# Altium schematic sheet schematic.SchDoc
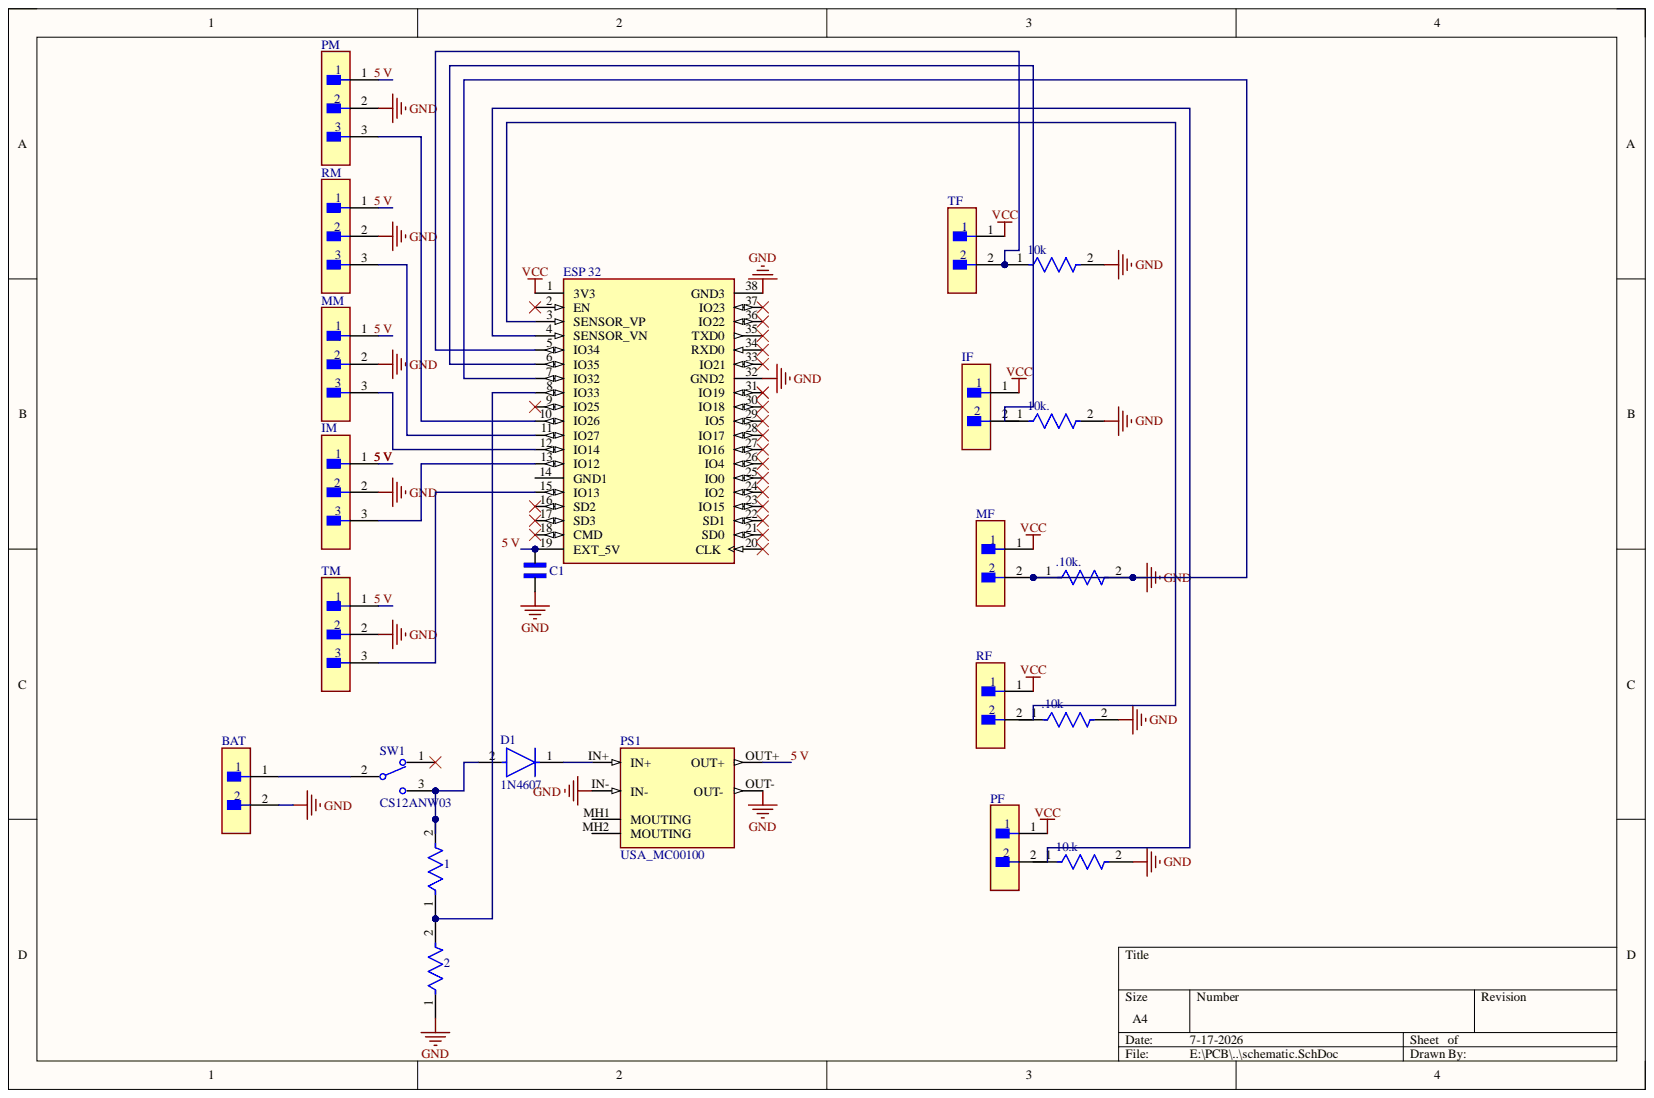
[diagram p0: schematic.SchDoc]

## Parts
1  VR37000001204JA100  [FP-VR37-MFG]  (value not drawn)
2  VR37000001204JA100  [FP-VR37-MFG]  (value not drawn)
10.k  VR37000001204JA100  [FP-VR37-MFG]  (value not drawn)
10k  VR37000001204JA100  [FP-VR37-MFG]  (value not drawn)
10k.  VR37000001204JA100  [FP-VR37-MFG]  (value not drawn)
.10k  VR37000001204JA100  [FP-VR37-MFG]  (value not drawn)
.10k.  VR37000001204JA100  [FP-VR37-MFG]  (value not drawn)
BAT  TSW-102-14-G-S  [FP-TSW-102-14-G-S-MFG]  (value not drawn)
C1  FA14X8R1H104KNU06  [CAPRB250W50L450T300H550]  (value not drawn)
D1  1N4607  [FP-DO-35-MFG]
ESP_32  ESP32-DEVKITC  [MODULE_ESP32-DEVKITC]  (value not drawn)
IF  TSW-102-14-G-S  [FP-TSW-102-14-G-S-MFG]  (value not drawn)
IM  NRPN031PAEN-RC  [FP-NRPN031PAEN-RC-MFG]  (value not drawn)
MF  TSW-102-14-G-S  [FP-TSW-102-14-G-S-MFG]  (value not drawn)
MM  NRPN031PAEN-RC  [FP-NRPN031PAEN-RC-MFG]  (value not drawn)
PF  TSW-102-14-G-S  [FP-TSW-102-14-G-S-MFG]  (value not drawn)
PM  NRPN031PAEN-RC  [FP-NRPN031PAEN-RC-MFG]  (value not drawn)
PS1  USA_MC00100  [CONV_USA_MC00100]
RF  TSW-102-14-G-S  [FP-TSW-102-14-G-S-MFG]  (value not drawn)
RM  NRPN031PAEN-RC  [FP-NRPN031PAEN-RC-MFG]  (value not drawn)
SW1  CS12ANW03  [FP-CS12ANW03-MFG]
TF  TSW-102-14-G-S  [FP-TSW-102-14-G-S-MFG]  (value not drawn)
TM  NRPN031PAEN-RC  [FP-NRPN031PAEN-RC-MFG]  (value not drawn)

## Nets
GND: 2.1 10.k.2 10k.2 10k..2 .10k.2 .10k..1 .10k..2 BAT.2 C1.2 ESP_32.7(IO32) ESP_32.32(GND2) ESP_32.38(GND3) IM.2 MF.2 MM.2 PM.2 PS1.IN- PS1.OUT- RM.2 TM.2
VCC: ESP_32.1(3V3) IF.1 MF.1 PF.1 RF.1 TF.1
5 V: C1.1 ESP_32.19(EXT_5V) IM.1 MM.1 PM.1 PS1.OUT+ RM.1 TM.1
Net1_1: 1.1 2.2 ESP_32.8(IO33)
Net1_2: 1.2 D1.2 SW1.3
Net10k._1: 10k..1 ESP_32.6(IO35)
Net10k_1: 10k.1 ESP_32.5(IO34) TF.2
NetBAT_1: BAT.1 SW1.2
NetD1_1: D1.1 PS1.IN+
NetESP 32_3: ESP_32.3(SENSOR_VP) RF.2
NetESP 32_4: ESP_32.4(SENSOR_VN) PF.2
NetESP 32_10: ESP_32.10(IO26) PM.3
NetESP 32_11: ESP_32.11(IO27) RM.3
NetESP 32_12: ESP_32.12(IO14) MM.3
NetESP 32_13: ESP_32.13(IO12) IM.3
NetESP 32_15: ESP_32.15(IO13) TM.3

Not connected: 10.k 1; .10k 1; ESP_32 2(EN) 9(IO25) 14(GND1) 16(SD2) 17(SD3) 18(CMD) 20(CLK) 21(SD0) 22(SD1) 23(IO15) 24(IO2) 25(IO0) 26(IO4) 27(IO16) 28(IO17) 29(IO5) 30(IO18) 31(IO19) 33(IO21) 34(RXD0) 35(TXD0) 36(IO22) 37(IO23); IF 2; PS1 MH1(MOUTING) MH2(MOUTING); SW1 1

## Library data of the parts
1 2 10.k 10k 10k. .10k .10k. = CMP-02408-000054-1: RES 1.2M OHM 5% 1/2W AXIAL; Power Rating: 500mW; Resistance: 1.2MR; Temperature Coefficient: 200ppm/°C; Voltage Rating: 3.5kV; Case/Package: Conformal; Component Kind: Standard; Construction: Cylindrical; Contact Plating: Tin; Diameter: 4mm; Length: 10mm; Library Name: Altium Content Vault; Max Operating Temperature: 155°C; Military Standard: Not; Min Operating Temperature: -55°C; Mount: Through Hole; Packaging: Ammo Pack; Radiation Hardening: No; Reach SVHC Compliant: Yes; Resistance Tolerance: 5%; Resistor Type: Metal Film; Termination: Axial; Tolerance: 5%; Voltage Rating (DC): 3.5kV
BAT IF MF PF RF TF = CMP-02766-001740-1: CONN HEADER VERT 2POS 2.54MM; Gender: Male; Max Current Rating: 7.6A; Max Voltage Rating (AC): 550V; Number of Contacts: 2; Color: Black; Component Kind: Standard; Connector Type: Header; Contact Finish - Mating: GOLD; Contact Material: Bronze; Contact Mating Length: 0.32inch; Contact Plating: Gold; Contact Shape: Square; Contact Type: Male Pin; Depth: 2.54mm; Fastening Type: Pull; Flammability Rating: UL94 V-0; Housing Color: Black; Housing Material: Polyester; Insulation Color: Black; Insulation Material: PBT; Lead Free: Lead Free; Length: 5.08mm; Library Name: Altium Content Vault; Max Operating Temperature: 125°C; Min Operating Temperature: -55°C; Mount: Through Hole; Number of Positions: 2; Number of Rows: 1; Orientation: Straight; Package Quantity: 1; Packaging: Bulk; Pitch: 2.54mm; Radiation Hardening: No; REACH SVHC: No SVHC; RoHS Compliant: Yes; Series: TSW; Termination: Solder; Width: 2.54mm
C1 = FA14X8R1H104KNU06: 0.1 ÂµF Â±10% 50V Ceramic Capacitor X8R Radial; MF: TDK; Check_prices: https://www.snapeda.com/parts/FA14X8R1H104KNU06/TDK/view-part/?ref=eda; Component Kind: Standard; Library Name: ceramic capacitor.IntLib; MP: FA14X8R1H104KNU06; Package: NON STANDARD-2 TDK; SnapEDA_Link: https://www.snapeda.com/parts/FA14X8R1H104KNU06/TDK/view-part/?ref=snap
D1 = CMP-00252-99173177-1: 1N4607 1N4607 Series 85 V 0.2 A Axial Silicon Switching Diode - DO-35; Average Rectified Current: 200mA; Element Configuration: Single; Forward Voltage: 1.1V; Max Forward Surge Current (Ifsm): 1A; Max Repetitive Reverse Voltage (Vrrm): 85V; Peak Reverse Current: 100µA; Reverse Recovery Time: 10ns; Component Kind: Standard; Contact Plating: Lead, Tin; Forward Current: 200mA; Height: 2.286mm; Library Name: Altium Content Vault; Max Operating Temperature: 200°C; Max Reverse Voltage (DC): 85V; Min Operating Temperature: -65°C; Mount: Through Hole; Number of Pins: 2; Packaging: Bulk; Peak Non-Repetitive Surge Current: 1A; Radiation Hardening: No; Reverse Voltage: 85V; RoHS: Compliant; Schedule B: 8541100070, 8541210080, 8541210080
ESP_32 = ESP32-DEVKITC: Transceiver; 802.11 b/g/n (Wi-Fi, WiFi, WLAN), BluetoothÂ® Smart Ready 4.x Dual Mode; MF: Espressif Systems; MP: ESP32-DEVKITC-32D-F; Check_prices: https://www.snapeda.com/parts/ESP32-DEVKITC-32D-F/Espressif+Systems/view-part/?ref=eda; Component Kind: Standard; Library Name: ESP32-DEVKITC.IntLib; Package: None; SnapEDA_Link: https://www.snapeda.com/parts/ESP32-DEVKITC-32D-F/Espressif+Systems/view-part/?ref=snap
IM MM PM RM TM = CMP-25680-000021-1: CONN HEADER VERT 3POS 2MM; Manufacturer: Sullins; Conn Gender: Male; Conn Orientation: Straight; Current Rating: 2A; Number Of Contacts: 3; Voltage Rating: 150V; Automotive: No; Category: Conn; Component Kind: Standard; ComponentLink2Description: Datasheet; ComponentLink2URL: https://drawings-pdf.s3.amazonaws.com/10531.pdf; Contact Material: Gold,Nickel; Device Class L1: Connectors; Device Class L2: Headers and Wire Housings; Device Class L3: unset; Digikey Description: CONN HEADER VERT 3POS 2MM; Height: 6mm; Lead Free: Yes; Library Name: Altium Content Vault; Manufacturer Part Number: NRPN031PAEN-RC; Number Of Rows: 1; Octopart: https://octopart.com/nrpn031paen-rc-sullins-12817732; Package: 0; Pitch: 2mm; RoHS: TRUE; Temperature: -40°C to +105°C; Temperature Range High: +105°C; Temperature Range Low: -40°C
PS1 = USA_MC00100: DC-DC LM2596 Step Down Adjustable Converter Power Supply Module 1.3V-35V; MF: YKS; Check_prices: https://www.snapeda.com/parts/USA_MC00100/YKS/view-part/?ref=eda; Component Kind: Standard; MP: USA_MC00100; Package: None; SnapEDA_Link: https://www.snapeda.com/parts/USA_MC00100/YKS/view-part/?ref=snap; Library Name: BUCK CONVERTOR 1.IntLib
SW1 = CMP-31746-000002-1: SWITCH SLIDE SPDT 3A 125V; Actuator Length: 5.1mm; Actuator Material: Nylon; Actuator Type: Standard; Contact Style: Non-Shorting; Electrical Life: 20000Cycles; Illumination Type: Non-Illuminated; Max Voltage Rating (AC): 250V; Mechanical Life: 50000Cycles; Switch Function: On-On; Switch Travel: 2.2mm; Component Kind: Standard; Contact Current Rating: 3A; Contact Material: Copper; Contact Plating: Silver; Current Rating: 3A; Depth: 7.5mm; Flammability Rating: UL94 V-0; Lead Free: Lead Free; Length: 14mm; Library Name: Altium Content Vault; Max Current Rating: 3A; Max Operating Temperature: 85°C; Min Operating Temperature: -30°C; Mount: Through Hole; Orientation: Vertical; Package Quantity: 1; Packaging: Tray; Primary Type: SWITCH; Reach SVHC Compliant: Yes; RoHS Compliant: Yes; Series: CS; Termination: PC Pin; Throw Configuration: SPDT; Voltage Rating (AC): 125V
